# Altium schematic sheet FPC12_GC9B72NA+IVO2.86_65pin.SchDoc
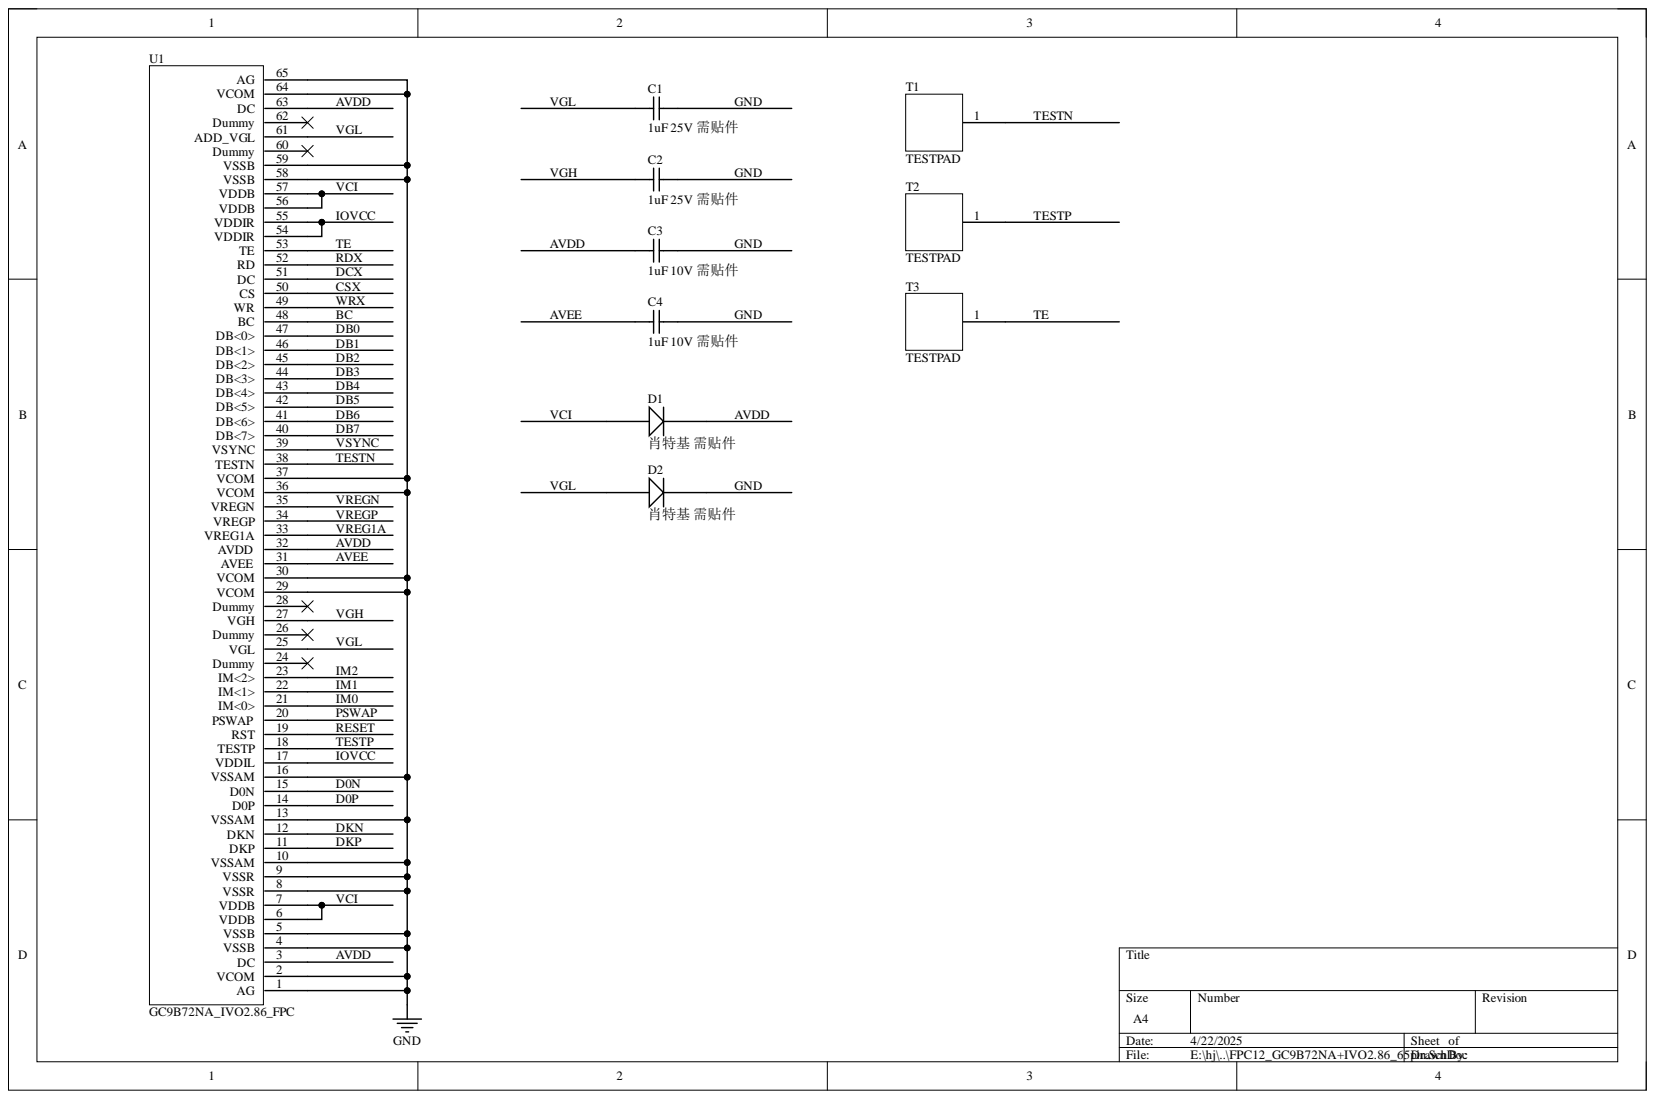
[diagram p0: FPC12_GC9B72NA+IVO2.86_65pin.SchDoc]

## Parts
C1  1uF 25V 需贴件  [0402]
C2  1uF 25V 需贴件  [0402]
C3  1uF 10V 需贴件  [0402]
C4  1uF 10V 需贴件  [0402]
D1  肖特基 需贴件
D2  肖特基 需贴件
T1  TESTPAD  [Test_point]
T2  TESTPAD  [Test_point]
T3  TESTPAD  [Test_point]
U1  GC9B72NA_IVO2.86_FPC

## Nets
AVDD: C3.1 U1.3(DC) U1.32(AVDD) U1.63(DC)
AVEE: C4.1 U1.31(AVEE)
BC: U1.48(BC)
CSX: U1.50(CS)
D0N: U1.15(D0N)
D0P: U1.14(D0P)
DB0: U1.47(DB<0>)
DB1: U1.46(DB<1>)
DB2: U1.45(DB<2>)
DB3: U1.44(DB<3>)
DB4: U1.43(DB<4>)
DB5: U1.42(DB<5>)
DB6: U1.41(DB<6>)
DB7: U1.40(DB<7>)
DCX: U1.51(DC)
DKN: U1.12(DKN)
DKP: U1.11(DKP)
GND: C1.2 C2.2 C3.2 C4.2 U1.1(AG) U1.2(VCOM) U1.4(VSSB) U1.5(VSSB) U1.8(VSSR) U1.9(VSSR) U1.10(VSSAM) U1.13(VSSAM) U1.16(VSSAM) U1.29(VCOM) U1.30(VCOM) U1.36(VCOM) U1.37(VCOM) U1.58(VSSB) U1.59(VSSB) U1.64(VCOM) U1.65(AG)
IM0: U1.21(IM<0>)
IM1: U1.22(IM<1>)
IM2: U1.23(IM<2>)
IOVCC: U1.17(VDDIL) U1.54(VDDIR) U1.55(VDDIR)
PSWAP: U1.20(PSWAP)
RDX: U1.52(RD)
RESET: U1.19(RST)
TE: T3.1 U1.53(TE)
TESTN: T1.1 U1.38(TESTN)
TESTP: T2.1 U1.18(TESTP)
VCI: U1.6(VDDB) U1.7(VDDB) U1.56(VDDB) U1.57(VDDB)
VGH: C2.1 U1.27(VGH)
VGL: C1.1 U1.25(VGL) U1.61(ADD_VGL)
VREG1A: U1.33(VREG1A)
VREGN: U1.35(VREGN)
VREGP: U1.34(VREGP)
VSYNC: U1.39(VSYNC)
WRX: U1.49(WR)

Not connected: D1 17 18; D2 17 18; U1 24(Dummy) 26(Dummy) 28(Dummy) 60(Dummy) 62(Dummy)

## Library data of the parts
C1-C4 = 0402 1.0uF (105) 10% 25V: 贴片电容; Component Kind: Standard; ComponentLink1Description: 供应商链接; ComponentLink1URL: http://www.szlcsc.com/product/details_53938.html; Library Name: Miscellaneous Devices LC.IntLib; Package: 0402; Supplier: LC; SuppliersPartNumber: C52923
D1 D2 = Component_2: Component Kind: Standard; Library Name: FPC12_GC9B72NA+IVO2.86_65pin.SchLib
U1 = Component_1: Component Kind: Standard; Library Name: FPC12_GC9B72NA+IVO2.86_65pin.SchLib
T1-T3 = TESTPAD: Component Kind: Standard; Library Name: GC9505_CSOT4.3_FullPin_V01.SchLib
